# Altium schematic sheet LED_AGV_30KG.SchDoc(LED_AGV_30KG)
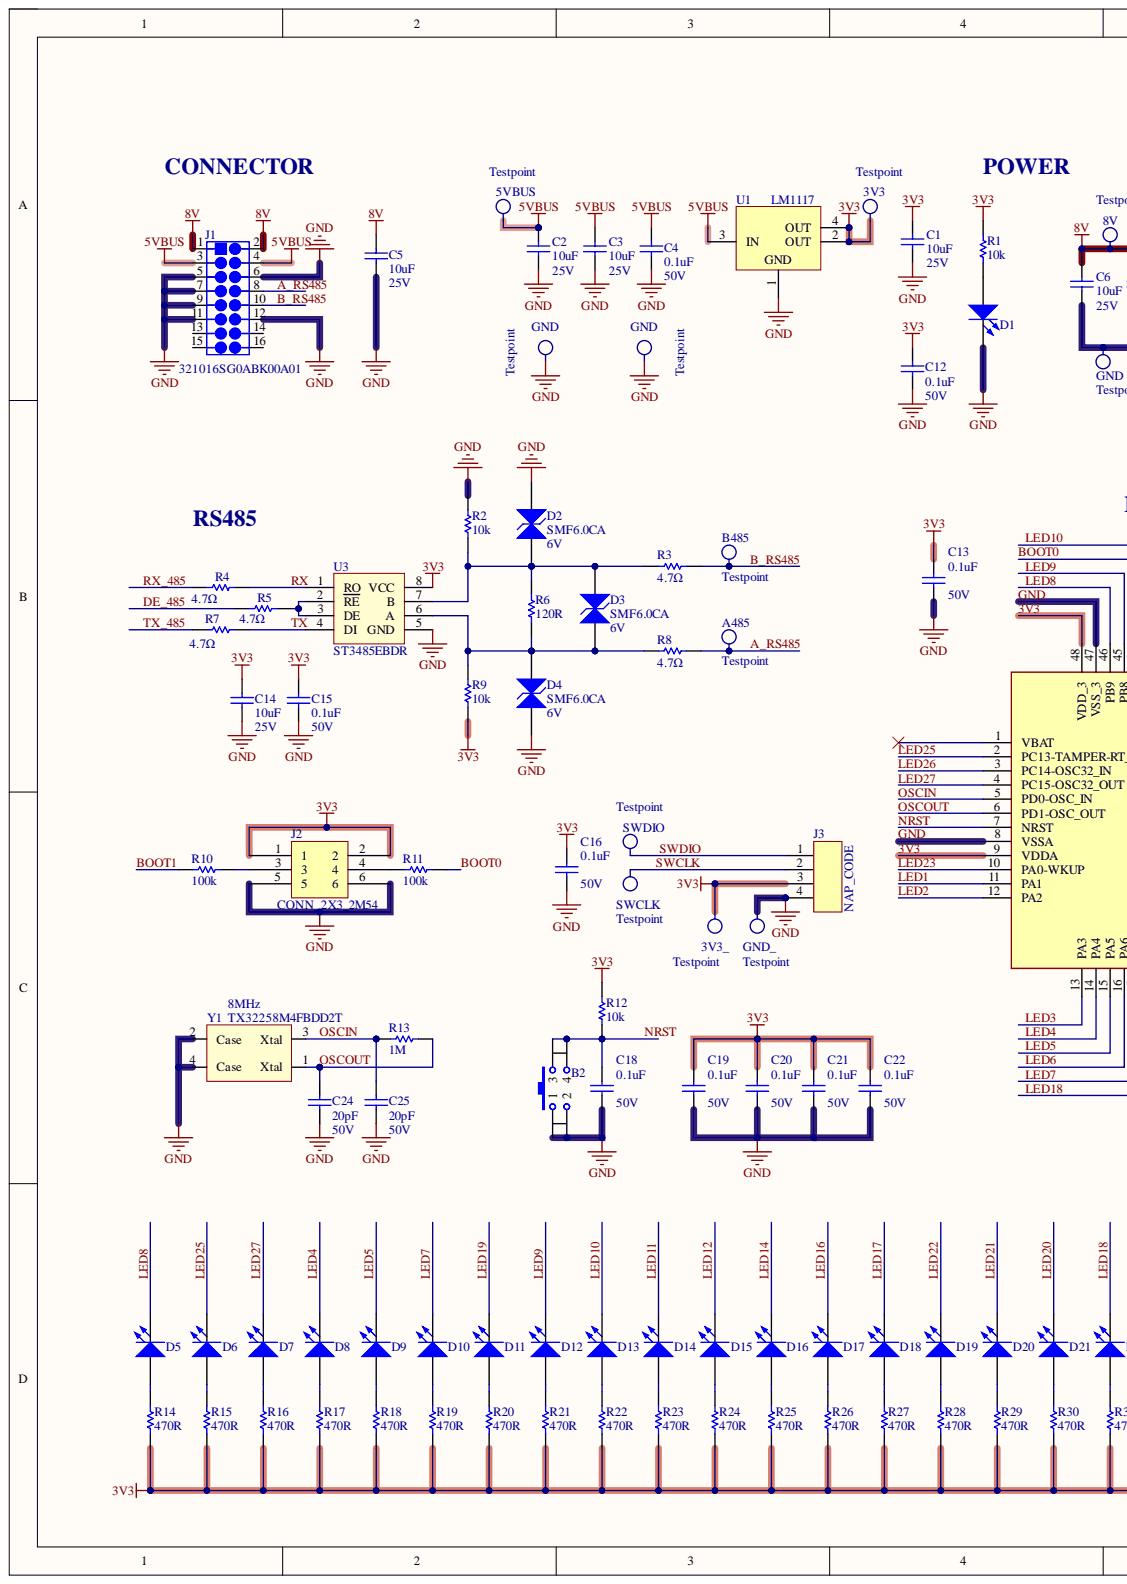
[diagram p0: LED_AGV_30KG.SchDoc, left]
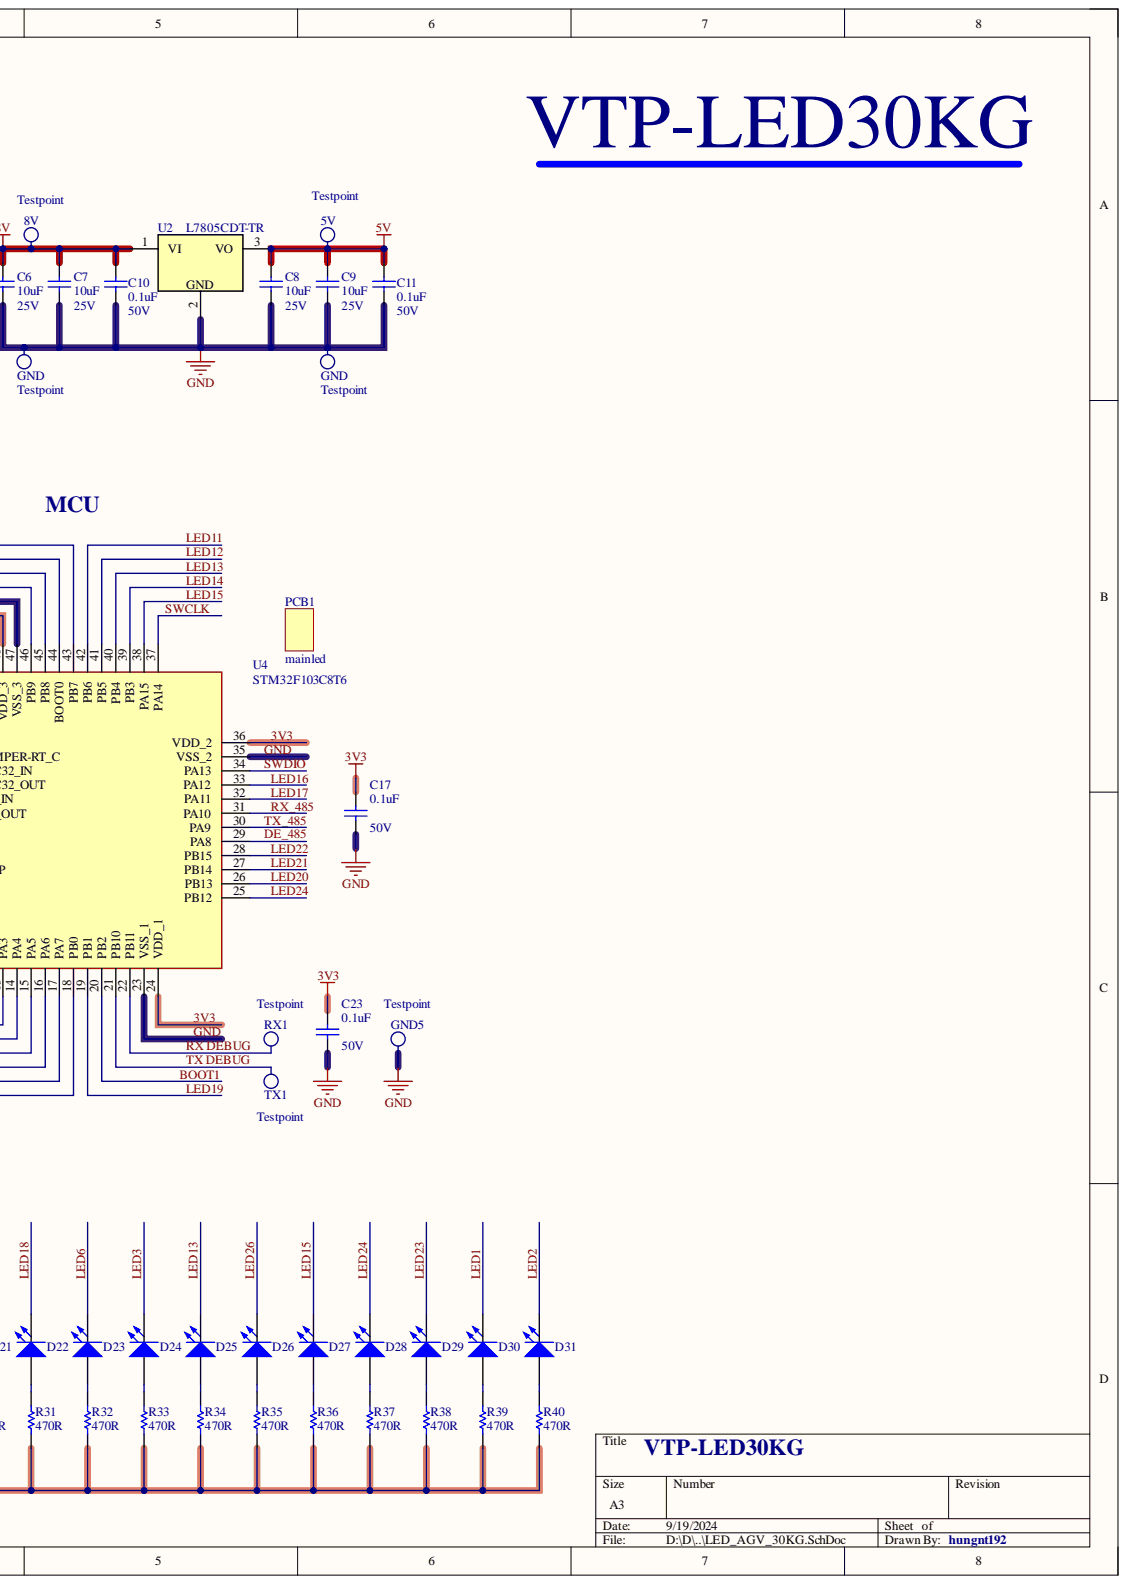
[diagram p1: LED_AGV_30KG.SchDoc, right]

## Parts
3V3  Testpoint  [Testpoint_signal]
3V3_  Testpoint  [Testpoint_signal]
5V  Testpoint  [Testpoint_signal]
5VBUS  Testpoint  [Testpoint_signal]
8V  Testpoint  [Testpoint_signal]
A485  Testpoint  [Testpoint_signal]
B2  button SMD 6x6x5  [button 250 smd]  (value not drawn)
B485  Testpoint  [Testpoint_signal]
C1  10uF  Voltage: 25V  [CAP_SMD_0805]
C2  10uF  Voltage: 25V  [CAP_SMD_0805]
C3  10uF  Voltage: 25V  [CAP_SMD_0805]
C4  0.1uF  Voltage: 50V  [CAP_SMD_0805]
C5  10uF  Voltage: 25V  [CAP_SMD_0805]
C6  10uF  Voltage: 25V  [CAP_SMD_0805]
C7  10uF  Voltage: 25V  [CAP_SMD_0805]
C8  10uF  Voltage: 25V  [CAP_SMD_0805]
C9  10uF  Voltage: 25V  [CAP_SMD_0805]
C10  0.1uF  Voltage: 50V  [CAP_SMD_0805]
C11  0.1uF  Voltage: 50V  [CAP_SMD_0805]
C12  0.1uF  Voltage: 50V  [CAP_SMD_0805]
C13  0.1uF  Voltage: 50V  [CAP_SMD_0805]
C14  10uF  Voltage: 25V  [CAP_SMD_0805]
C15  0.1uF  Voltage: 50V  [CAP_SMD_0805]
C16  0.1uF  Voltage: 50V  [CAP_SMD_0805]
C17  0.1uF  Voltage: 50V  [CAP_SMD_0805]
C18  0.1uF  Voltage: 50V  [CAP_SMD_0805]
C19  0.1uF  Voltage: 50V  [CAP_SMD_0805]
C20  0.1uF  Voltage: 50V  [CAP_SMD_0805]
C21  0.1uF  Voltage: 50V  [CAP_SMD_0805]
C22  0.1uF  Voltage: 50V  [CAP_SMD_0805]
C23  0.1uF  Voltage: 50V  [CAP_SMD_0805]
C24  20pF  Voltage: 50V  [CAP_SMD_0805]
C25  20pF  Voltage: 50V  [CAP_SMD_0805]
D1  LED RED SMD  [LED_SMD0805]  (value not drawn)
D2  SMF6.0CA  Voltage: 6V  [SOD-123]
D3  SMF6.0CA  Voltage: 6V  [SOD-123]
D4  SMF6.0CA  Voltage: 6V  [SOD-123]
D5  LED RED SMD  [LED_SMD0805]  (value not drawn)
D6  LED RED SMD  [LED_SMD0805]  (value not drawn)
D7  LED RED SMD  [LED_SMD0805]  (value not drawn)
D8  LED RED SMD  [LED_SMD0805]  (value not drawn)
D9  LED RED SMD  [LED_SMD0805]  (value not drawn)
D10  LED RED SMD  [LED_SMD0805]  (value not drawn)
D11  LED RED SMD  [LED_SMD0805]  (value not drawn)
D12  LED RED SMD  [LED_SMD0805]  (value not drawn)
D13  LED RED SMD  [LED_SMD0805]  (value not drawn)
D14  LED RED SMD  [LED_SMD0805]  (value not drawn)
D15  LED RED SMD  [LED_SMD0805]  (value not drawn)
D16  LED RED SMD  [LED_SMD0805]  (value not drawn)
D17  LED RED SMD  [LED_SMD0805]  (value not drawn)
D18  LED RED SMD  [LED_SMD0805]  (value not drawn)
D19  LED RED SMD  [LED_SMD0805]  (value not drawn)
D20  LED RED SMD  [LED_SMD0805]  (value not drawn)
D21  LED RED SMD  [LED_SMD0805]  (value not drawn)
D22  LED RED SMD  [LED_SMD0805]  (value not drawn)
D23  LED RED SMD  [LED_SMD0805]  (value not drawn)
D24  LED RED SMD  [LED_SMD0805]  (value not drawn)
D25  LED RED SMD  [LED_SMD0805]  (value not drawn)
D26  LED RED SMD  [LED_SMD0805]  (value not drawn)
D27  LED RED SMD  [LED_SMD0805]  (value not drawn)
D28  LED RED SMD  [LED_SMD0805]  (value not drawn)
D29  LED RED SMD  [LED_SMD0805]  (value not drawn)
D30  LED RED SMD  [LED_SMD0805]  (value not drawn)
D31  LED RED SMD  [LED_SMD0805]  (value not drawn)
GND  Testpoint  [Testpoint_signal]
GND  Testpoint  [Testpoint_signal]
GND  Testpoint  [Testpoint_signal]
GND  Testpoint  [Testpoint_signal]
GND5  Testpoint  [Testpoint_signal]
GND_  Testpoint  [Testpoint_signal]
J1  Mfr. Part # 1: 321016SG0ABK00A01  [Header IDC 8x2 2.54mm through hold]  (hidden comment: header16 8x2 2.54mm)
J2  CONN_2X3_2M54  [HDR_2X3_2m54_7m62x4m8x10m5]
J3  NAP_CODE  [HDR 4PIN 2.5 XHB-4A]
PCB1  mainled  (mechanical)
R1  10k  [RES_SMD_0805]
R2  10k  [RES_SMD_0805]
R3  value 4.7Ω  [RES_SMD_0805]  (hidden comment: 4.7R)
R4  value 4.7Ω  [RES_SMD_0805]  (hidden comment: 4.7R)
R5  value 4.7Ω  [RES_SMD_0805]  (hidden comment: 4.7R)
R6  120R  [RES_SMD_0805]
R7  value 4.7Ω  [RES_SMD_0805]  (hidden comment: 4.7R)
R8  value 4.7Ω  [RES_SMD_0805]  (hidden comment: 4.7R)
R9  10k  [RES_SMD_0805]
R10  100k  [RES_SMD_0805]
R11  100k  [RES_SMD_0805]
R12  10k  [RES_SMD_0805]
R13  1M  [RES_SMD_0805]
R14  470R  [RES_SMD_0805]
R15  470R  [RES_SMD_0805]
R16  470R  [RES_SMD_0805]
R17  470R  [RES_SMD_0805]
R18  470R  [RES_SMD_0805]
R19  470R  [RES_SMD_0805]
R20  470R  [RES_SMD_0805]
R21  470R  [RES_SMD_0805]
R22  470R  [RES_SMD_0805]
R23  470R  [RES_SMD_0805]
R24  470R  [RES_SMD_0805]
R25  470R  [RES_SMD_0805]
R26  470R  [RES_SMD_0805]
R27  470R  [RES_SMD_0805]
R28  470R  [RES_SMD_0805]
R29  470R  [RES_SMD_0805]
R30  470R  [RES_SMD_0805]
R31  470R  [RES_SMD_0805]
R32  470R  [RES_SMD_0805]
R33  470R  [RES_SMD_0805]
R34  470R  [RES_SMD_0805]
R35  470R  [RES_SMD_0805]
R36  470R  [RES_SMD_0805]
R37  470R  [RES_SMD_0805]
R38  470R  [RES_SMD_0805]
R39  470R  [RES_SMD_0805]
R40  470R  [RES_SMD_0805]
RX1  Testpoint  [Testpoint_signal]
SWCLK  Testpoint  [Testpoint_signal]
SWDIO  Testpoint  [Testpoint_signal]
TX1  Testpoint  [Testpoint_signal]
U1  LM1117  [SOT223]
U2  L7805CDT-TR  [DPAK_118_446_112X59_SMD]
U3  ST3485EBDR  [SOIC8]
U4  STM32F103C8T6  [LQFP-48_19.6_393_58X11_SMD]
Y1  8MHz  Mfr. Part # 1: TX32258M4FBDD2T  [Crystal 3.2x2.5mm 4Pin]

## Nets
5V: 5V.2 C8.1 C9.1 C11.2 U2.3
5VBUS: 5VBUS.2 C2.1 C3.1 C4.2 J1.3 J1.4 U1.3(IN)
8V: 8V.2 C5.1 C6.1 C7.1 C10.2 J1.1 J1.2 U2.1
3V3: 3V3.2 3V3_.2 C1.1 C12.2 C13.2 C14.1 C15.2 C16.2 C17.2 C19.2 C20.2 C21.2 C22.2 C23.2 J2.1 J2.2 J3.3 R1.1 R9.1 R12.1 R14.1 R15.1 R16.1 R17.1 R18.1 R19.1 R20.1 R21.1 R22.1 R23.1 R24.1 R25.1 R26.1 R27.1 R28.1 R29.1 R30.1 R31.1 R32.1 R33.1 R34.1 R35.1 R36.1 R37.1 R38.1 R39.1 R40.1 U1.2(OUT) U1.4(OUT) U3.8(VCC) U4.9(VDDA) U4.24(VDD_1) U4.36(VDD_2) U4.48(VDD_3)
A_RS485: A485.2 J1.8 R8.1
B_RS485: B485.2 J1.10 R3.1
BOOT0: R11.2 U4.44(BOOT0)
BOOT1: R10.2 U4.20(PB2)
DE_485: R5.2 U4.29(PA8)
GND: B2.1 B2.2 C1.2 C2.2 C3.2 C4.1 C5.2 C6.2 C7.2 C8.2 C9.2 C10.1 C11.1 C12.1 C13.1 C14.2 C15.1 C16.1 C17.1 C18.1 C19.1 C20.1 C21.1 C22.1 C23.1 C24.1 C25.1 D1.1 D2.1 D4.2 GND.2 GND5.2 GND_.2 J1.5 J1.6 J1.7 J1.9 J1.11 J1.12 J2.5 J2.6 J3.4 R2.2 U1.1 U2.2 U3.5(GND) U4.8(VSSA) U4.23(VSS_1) U4.35(VSS_2) U4.47(VSS_3) Y1.2(Case) Y1.4(Case)
LED1: D30.1 U4.11(PA1)
LED2: D31.1 U4.12(PA2)
LED3: D24.1 U4.13(PA3)
LED4: D8.1 U4.14(PA4)
LED5: D9.1 U4.15(PA5)
LED6: D23.1 U4.16(PA6)
LED7: D10.1 U4.17(PA7)
LED8: D5.1 U4.46(PB9)
LED9: D12.1 U4.45(PB8)
LED10: D13.1 U4.43(PB7)
LED11: D14.1 U4.42(PB6)
LED12: D15.1 U4.41(PB5)
LED13: D25.1 U4.40(PB4)
LED14: D16.1 U4.39(PB3)
LED15: D27.1 U4.38(PA15)
LED16: D17.1 U4.33(PA12)
LED17: D18.1 U4.32(PA11)
LED18: D22.1 U4.18(PB0)
LED19: D11.1 U4.19(PB1)
LED20: D21.1 U4.26(PB13)
LED21: D20.1 U4.27(PB14)
LED22: D19.1 U4.28(PB15)
LED23: D29.1 U4.10(PA0-WKUP)
LED24: D28.1 U4.25(PB12)
LED25: D6.1 U4.2(PC13-TAMPER-RT_C)
LED26: D26.1 U4.3(PC14-OSC32_IN)
LED27: D7.1 U4.4(PC15-OSC32_OUT)
NRST: B2.3 B2.4 C18.2 R12.2 U4.7(NRST)
OSCIN: C25.2 R13.1 U4.5(PD0-OSC_IN) Y1.3(Xtal)
OSCOUT: C24.2 R13.2 U4.6(PD1-OSC_OUT) Y1.1(Xtal)
RX: R4.1 U3.1(RO)
RX DEBUG: RX1.2 U4.22(PB11)
RX_485: R4.2 U4.31(PA10)
SWCLK: J3.2 SWCLK.2 U4.37(PA14)
SWDIO: J3.1 SWDIO.2 U4.34(PA13)
TX: R7.1 U3.4(DI)
TX DEBUG: TX1.2 U4.21(PB10)
TX_485: R7.2 U4.30(PA9)
NetD1_2: D1.2 R1.2
NetD2_2: D2.2 D3.1 R2.1 R3.2 R6.2 U3.7(B)
NetD3_2: D3.2 D4.1 R6.1 R8.2 R9.2 U3.6(A)
NetD5_2: D5.2 R14.2
NetD6_2: D6.2 R15.2
NetD7_2: D7.2 R16.2
NetD8_2: D8.2 R17.2
NetD9_2: D9.2 R18.2
NetD10_2: D10.2 R19.2
NetD11_2: D11.2 R20.2
NetD12_2: D12.2 R21.2
NetD13_2: D13.2 R22.2
NetD14_2: D14.2 R23.2
NetD15_2: D15.2 R24.2
NetD16_2: D16.2 R25.2
NetD17_2: D17.2 R26.2
NetD18_2: D18.2 R27.2
NetD19_2: D19.2 R28.2
NetD20_2: D20.2 R29.2
NetD21_2: D21.2 R30.2
NetD22_2: D22.2 R31.2
NetD23_2: D23.2 R32.2
NetD24_2: D24.2 R33.2
NetD25_2: D25.2 R34.2
NetD26_2: D26.2 R35.2
NetD27_2: D27.2 R36.2
NetD28_2: D28.2 R37.2
NetD29_2: D29.2 R38.2
NetD30_2: D30.2 R39.2
NetD31_2: D31.2 R40.2
NetJ2_3: J2.3 R10.1
NetJ2_4: J2.4 R11.1
NetR5_1: R5.1 U3.2(RE) U3.3(DE)

Not connected: J1 13 14 15 16; U4 1(VBAT)

## Library data of the parts
3V3 3V3_ 5V 5VBUS 8V A485 B485 GND GND GND GND GND5 GND_ RX1 SWCLK SWDIO TX1 = T?: By: hungnt192; NI Mark: No; Process Type: N/C; Category: Testpoint; Component Kind: Standard; Description: Testpoint; Library Name: Testpoint_signal.IntLib
B2 = button 250_SMD: SPST 50mA @ 12VDC Vertical Round Button SMD,6x6x5mm Tactile Switches RoHS; Manufacturer 1: HYP (Hongyuan Precision); Mfr. Part # 1: 1TS005F-2500-5001; By: hungnt192; NI Mark: Yes; Process Type: SM; Unit: PCS; Category: Button/Switch; Component Kind: Standard; Manufacturer 2: XUNPU; Mfr. Part # 2: TS-1002S-04526C; Package: 6x6x5mm; Packaging: Tape & Reel; Supplier 1: LCSC; Supplier 2: LCSC; Library Name: center board V1 Pi4.IntLib
C1-C3 C5-C9 C14 = Cap-NP-100: 25V 10uF X5R ±10% 0805 Multilayer Ceramic Capacitors MLCC - SMD/SMT ROHS; Manufacturer 1: YAGEO; Mfr. Part # 1: CC0805KKX5R8BB106; By: hungnt192; NI Mark: Yes; Process Type: SM; Unit: PCS; Category: Cap Cereramic; Component Kind: Standard; Library Name: Full.SchLib; Manufacturer 2: FH (Guangdong Fenghua Advanced Tech); Material: X5R; Mfr. Part # 2: 0805X106K250NT; Package: 0805; Packaging: Tape & Reel; Supplier 1: LCSC; Supplier 2: LCSC; Value: 10uF
C4 C10-C13 C15-C23 = Cap-NP-100: 100nF ±10% 50V X7R 0805 Multilayer Ceramic Capacitors MLCC - SMD/SMT RoHS; Manufacturer 1: YAGEO; Mfr. Part # 1: CC0805KRX7R9BB104; By: hungnt192; NI Mark: Yes; Process Type: SM; Unit: PCS; Category: Cap Cereramic; Component Kind: Standard; Library Name: Full.SchLib; Manufacturer 2: FH (Guangdong Fenghua Advanced Tech); Material: X7R; Mfr. Part # 2: 0805B104K500NT; Package: 0805; Packaging: Tape & Reel; Supplier 1: LCSC; Supplier 2: LCSC; Value: 0.1uF
C24 C25 = Cap-NP-100: 50V 20pF NP0 ±5% 0805 Multilayer Ceramic Capacitors MLCC - SMD/SMT ROHS; Manufacturer 1: YAGEO; Mfr. Part # 1: CC0805JRNPO9BN200; By: hungnt192; NI Mark: Yes; Process Type: SM; Unit: PCS; Category: Cap Cereramic; Component Kind: Standard; Library Name: Full.SchLib; Manufacturer 2: FH (Guangdong Fenghua Advanced Tech); Material: NP0; Mfr. Part # 2: 0805CG200J500NT; Package: 0805; Packaging: Tape & Reel; Supplier 1: LCSC; Supplier 2: LCSC; Value: 20pF
D1 D5-D31 = LED_RED_SMD_0805: 20mA 230mcd Colorless transparence -20℃~+85℃ Positive post 615nm~630nm Red 120° 55mW 2.3V 0805 Light Emitting Diodes (LED) ROHS; Manufacturer 1: XINGLIGHT; Mfr. Part # 1: XL-2012SURC; By: hungnt192; NI Mark: Yes; Process Type: SM; Unit: PCS; Value: LED RED; Category: LED; Component Kind: Standard; Manufacturer 2: Everlight Elec; Mfr. Part # 2: 17-21/R6C-AQ2R2B/4T; Package: 0805; Packaging: Tape & Reel; Supplier 1: LCSC; Supplier 2: LCSC; Voltage: 2.3V
  D1: Library Name: Full.SchLib
  D5-D31: Library Name: center board V1 Pi4.IntLib
D2-D4 = TVS SMF05CA  SOD123: Bidirectional 6V 6.67V 10.3V 19.4mA SOD-123FL TVS RoHS; Manufacturer 1: SUNMATE Elec; By: hungnt192; NI Mark: Yes; Process Type: SM; Unit: PCS; Category: ESD/TVS; Component Kind: Standard; Library Name: Full.SchLib; Manufacturer 2: ZHIDE; Mfr. Part # 1: SMF6.0CA; Mfr. Part # 2: SMF6.0CA; Package: SOD-123; Packaging: Tape & Reel; Supplier 1: LCSC; Supplier 2: LCSC
J1 = header 8x2 JILN: 16 2 0.100"(2.54mm) Header Through Hole,P=2.54mm IDC Connectors ROHS; Manufacturer 1: JILN; By: hungnt192; NI Mark: Yes; Process Type: SM; Unit: PCS; Category: Connector; Component Kind: Standard; Library Name: Full.SchLib; Manufacturer 2: Ckmtw(Shenzhen Cankemeng); Mfr. Part # 2: B-3000S16P-0110; Package: Header IDC 8x2; Packaging: Tray; Supplier 1: LCSC; Supplier 2: LCSC
J2 = CONN 2X3 MALE 2.54MM: CONN HEADER 6POS .100 STR 30AU; Manufacturer 1: XKB Connection; Mfr. Part # 1: X6521WV-2x03H-C60D30; By: hungnt192; NI Mark: Yes; Process Type: MA; Unit: PCS; Category: Connectors, Interconnects; Component Kind: Standard; Manufacturer 2: Liansheng; Mfr. Part # 2: PH-00219; Package: Plugin,P=2.54mm; Packaging: Bag-packed; Supplier 1: Digi-Key; Supplier 2: LCSC; Library Name: RD VTP.IntLib
J3 = CON HDR 4 PIN 1X4 2.5MM XHB-4A: 4 Header XHB 0.098"(2.50mm) Male Pin Through Hole Wire To Board / Wire To Wire Connector ROHS; Manufacturer 1: BOOMELE(Boom Precision Elec); Mfr. Part # 1: XHB-4A; By: hungnt192; NI Mark: Yes; Process Type: MA; Unit: PCS; Category: Connectors; Component Kind: Standard; Manufacturer 2: DEALON; Mfr. Part # 2: XHB-4A; Package: Plugin,P=2.5mm; Packaging: Bag-packed; Supplier 1: LCSC; Supplier 2: LCSC; Library Name: center board V1 Pi4.IntLib
PCB1 = CON Housings 4PIN 1x4 XHB－4Y: FR4; 1.6mm; 1OZ; color MATTEBLACK; 2 layer; Silkscreen White;; Manufacturer 1: PNLAB; Mfr. Part # 1: VTP-LED30KG; By: hungnt192; Category: PCB; Supplier 1: PNLAB; Library Name: VTP_TruckBoxVolMea.SCHLIB
R1 R2 R9 R12 = Res0.3: 125mW Thick Film Resistors 150V ±100ppm/℃ ±1% 10kΩ 0805 Chip Resistor - Surface Mount ROHS; Manufacturer 1: YAGEO; Mfr. Part # 1: RC0805FR-0710KL; By: hungnt192; NI Mark: Yes; Process Type: SM; Unit: PCS; Category: Resistor; Component Kind: Standard; Library Name: Full.SchLib; Manufacturer 2: FH (Guangdong Fenghua Advanced Tech); Material: Thick Film; Mfr. Part # 2: RS-05K1002FT; Package: 0805; Packaging: Tape & Reel; Supplier 1: LCSC; Supplier 2: LCSC; Value: 10k; Voltage: 150V
R3-R5 R7 R8 = Res0.3: 125mW Thick Film Resistors 150V ±1% ±200ppm/℃ 4.7Ω 0805 Chip Resistor - Surface Mount ROHS; Manufacturer 1: YAGEO; Mfr. Part # 1: RC0805FR-074R7L; By: hungnt192; NI Mark: Yes; Process Type: SM; Unit: PCS; Category: Resistor; Component Kind: Standard; Library Name: Full.SchLib; Manufacturer 2: FH (Guangdong Fenghua Advanced Tech); Material: Thick Film; Mfr. Part # 2: RS-05L4R70FT; Package: 0805; Packaging: Tape & Reel; Supplier 1: LCSC; Supplier 2: LCSC; Voltage: 150V
R6 = Res0.3: 125mW Thick Film Resistors 150V ±100ppm/℃ ±1% 120Ω 0805 Chip Resistor - Surface Mount ROHS; Manufacturer 1: YAGEO; Mfr. Part # 1: RC0805FR-07120RL; By: hungnt192; NI Mark: Yes; Process Type: SM; Unit: PCS; Category: Resistor; Component Kind: Standard; Library Name: Full.SchLib; Manufacturer 2: FH (Guangdong Fenghua Advanced Tech); Material: Thick Film; Mfr. Part # 2: RS-05K1200FT; Package: 0805; Packaging: Tape & Reel; Supplier 1: LCSC; Supplier 2: LCSC; Value: 120R; Voltage: 150V
R10 R11 = Res0.3: 125mW Thick Film Resistors 150V ±100ppm/℃ ±1% 100kΩ 0805 Chip Resistor - Surface Mount ROHS; Manufacturer 1: YAGEO; Mfr. Part # 1: RC0805FR-07100KL; By: hungnt192; NI Mark: Yes; Process Type: SM; Unit: PCS; Category: Resistor; Component Kind: Standard; Library Name: Full.SchLib; Manufacturer 2: FH (Guangdong Fenghua Advanced Tech); Material: Thick Film; Mfr. Part # 2: RS-05K1003FT; Package: 0805; Packaging: Tape & Reel; Supplier 1: LCSC; Supplier 2: LCSC; Value: 100k; Voltage: 150V
R13 = Res0.3: 1W 1mΩ ±50ppm/℃ ±1% 0805 Current Sense Resistors/Shunt Resistors ROHS; Manufacturer 1: YAGEO; Mfr. Part # 1: RC0805FR-071ML; By: hungnt192; NI Mark: Yes; Process Type: SM; Unit: PCS; Voltage: 150V; Category: Resistor; Component Kind: Standard; Library Name: Full.SchLib; Manufacturer 2: FH (Guangdong Fenghua Advanced Tech); Material: Thick Film; Mfr. Part # 2: RS-05K1004FT; Package: 0805; Packaging: Tape & Reel; Supplier 1: LCSC; Supplier 2: LCSC; Value: 1M
R14-R40 = Res0.3: 125mW Thick Film Resistors 150V ±100ppm/℃ ±1% 470Ω 0805 Chip Resistor - Surface Mount ROHS; Manufacturer 1: YAGEO; Mfr. Part # 1: RC0805FR-07470RL; By: hungnt192; NI Mark: Yes; Process Type: SM; Unit: PCS; Category: Resistor; Component Kind: Standard; Library Name: Full.SchLib; Manufacturer 2: FH (Guangdong Fenghua Advanced Tech); Material: Thick Film; Mfr. Part # 2: RS-05K4700FT; Package: 0805; Packaging: Tape & Reel; Supplier 1: LCSC; Supplier 2: LCSC; Value: 470R; Voltage: 150V
U1 = LM1117: Fixed 18V 3.3V 1.4V @ 1A SOT-223 Dropout Regulators(LDO) RoHS; Manufacturer 1: Slkor(SLKORMICRO Elec.); Mfr. Part # 1: AMS1117-3.3; By: hungnt192; NI Mark: Yes; Process Type: SM; Unit: PCS; Category: IC; Component Kind: Standard; Library Name: Full.SchLib; Manufacturer 2: PUOLOP; Mfr. Part # 2: AMS1117-3.3; Package: SOT223; Packaging: Tape & Reel; Supplier 1: LCSC; Supplier 2: LCSC; Value: 3.3V; Voltage: 3.3V
U2 = REG_35V_5V-1.5A-7.5W-DPAK_1: 62dB@(120Hz) 1.5A Fixed 5V Positive electrode 35V DPAK Linear Voltage Regulators (LDO) ROHS; Manufacturer 1: STMicroelectronics; By: hungnt192; NI Mark: Yes; Process Type: SM; Unit: PCS; Category: IC; Component Kind: Standard; Manufacturer 2: STMicroelectronics; Mfr. Part # 1: L7805CDT-TR; Mfr. Part # 2: L7805CDT-TR; Package: DPAK; Packaging: Tape & Reel; Supplier 1: LCSC; Supplier 2: MOUSER; Value: 5V; Voltage: 5V; Library Name: Altium_Lib_by_KhueNguyenCreator.IntLib
U3 = RS 485 SIT3485ESA: Transceiver RS485 1/1 200kbps SOIC-8_150mil RS-485/RS-422 ICs RoHS; Manufacturer 1: STMicroelectronics; By: hungnt192; NI Mark: Yes; Process Type: SM; Unit: PCS; Voltage: 3.3V; Category: IC; Component Kind: Standard; Library Name: Full.SchLib; Manufacturer 2: UMW(Youtai Semiconductor Co., Ltd.); Mfr. Part # 1: ST3485EBDR; Mfr. Part # 2: ST3485EBDR; Package: SOP-8; Packaging: Tape & Reel; Supplier 1: LCSC; Supplier 2: LCSC; Supplier 3: Arrow
U4 = IC_STM32F103C8T6: ARM® Cortex®-M3 STM32F1 Microcontroller IC 32-Bit 72MHz 64KB (64K x 8) FLASH 48-LQFP (7x7); Manufacturer 1: STMicroelectronics; By: hungnt192; NI Mark: Yes; Process Type: SM; Unit: PCS; Voltage: 3.3V; Category: MCU; Component Kind: Standard; Manufacturer 2: STMicroelectronics; Mfr. Part # 1: STM32F103C8T6; Mfr. Part # 2: STM32F103C8T6; Package: LQFP-48(7x7); Packaging: Tray; Supplier 1: LCSC; Supplier 2: MOUSER; Library Name: Altium_Lib_by_KhueNguyenCreator.IntLib
Y1 = Crystal FL0800008Q: Crystal 3.2x2.5mm 4Pin; Manufacturer 1: Yajingxin; By: hungnt192; NI Mark: Yes; Process Type: SM; Unit: PCS; Category: Crystal; Component Kind: Standard; Library Name: Full.SchLib; Manufacturer 2: JWT; Mfr. Part # 2: CF4008M00012T8188031  C2933033; Package: SMD3225-4P; Packaging: Tape & Reel; Supplier 1: LCSC; Supplier 2: LCSC; Value: 8MHz
